# Altium schematic sheet VGA.SchDoc(VGA)
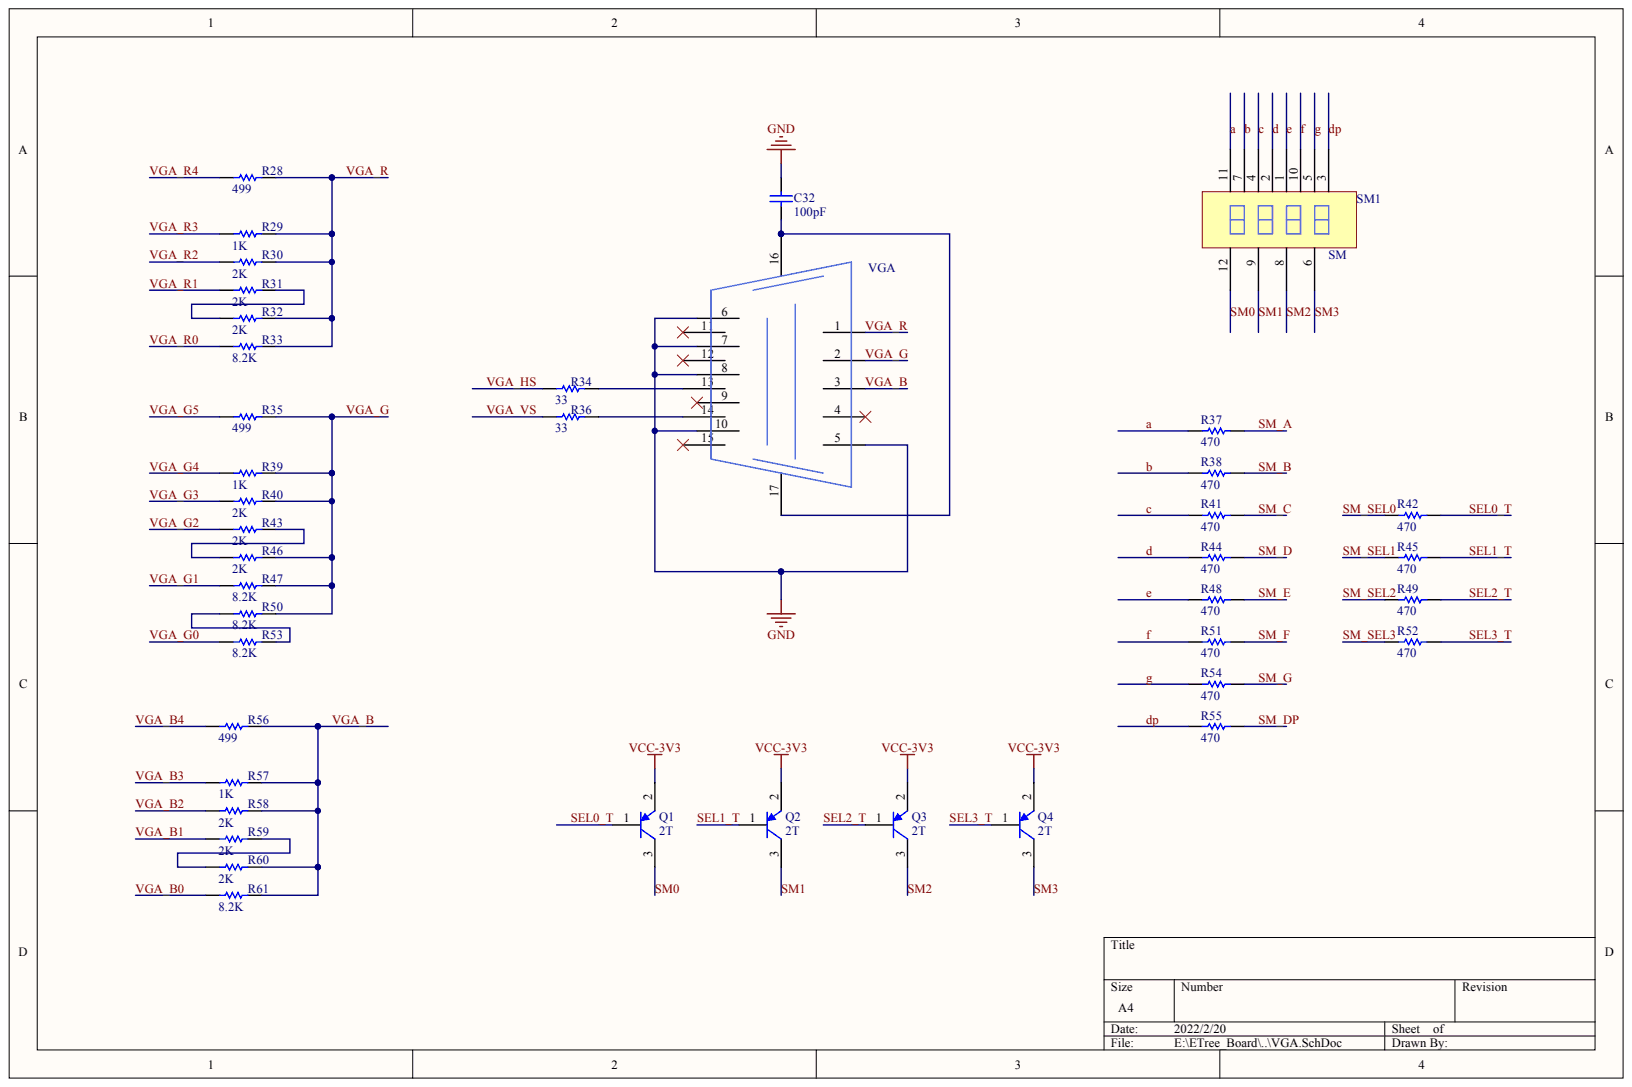
[diagram p0: VGA.SchDoc]

## Parts
C32  value 100pF  (hidden comment: Cap)
Q1  2T
Q2  2T
Q3  2T
Q4  2T
R28  value 499  (hidden comment: Res1)
R29  value 1K  (hidden comment: Res1)
R30  value 2K  (hidden comment: Res1)
R31  value 2K  (hidden comment: Res1)
R32  value 2K  (hidden comment: Res1)
R33  value 8.2K  (hidden comment: Res1)
R34  value 33  (hidden comment: Res1)
R35  value 499  (hidden comment: Res1)
R36  value 33  (hidden comment: Res1)
R37  value 470  (hidden comment: Res1)
R38  value 470  (hidden comment: Res1)
R39  value 1K  (hidden comment: Res1)
R40  value 2K  (hidden comment: Res1)
R41  value 470  (hidden comment: Res1)
R42  value 470  (hidden comment: Res1)
R43  value 2K  (hidden comment: Res1)
R44  value 470  (hidden comment: Res1)
R45  value 470  (hidden comment: Res1)
R46  value 2K  (hidden comment: Res1)
R47  value 8.2K  (hidden comment: Res1)
R48  value 470  (hidden comment: Res1)
R49  value 470  (hidden comment: Res1)
R50  value 8.2K  (hidden comment: Res1)
R51  value 470  (hidden comment: Res1)
R52  value 470  (hidden comment: Res1)
R53  value 8.2K  (hidden comment: Res1)
R54  value 470  (hidden comment: Res1)
R55  value 470  (hidden comment: Res1)
R56  value 499  (hidden comment: Res1)
R57  value 1K  (hidden comment: Res1)
R58  value 2K  (hidden comment: Res1)
R59  value 2K  (hidden comment: Res1)
R60  value 2K  (hidden comment: Res1)
R61  value 8.2K  (hidden comment: Res1)
SM1  SM
VGA1  VGA

## Nets
GND: C32.1 VGA1.5 VGA1.6 VGA1.7 VGA1.8 VGA1.10
VCC-3V3: Q1.2 Q2.2 Q3.2 Q4.2
A: R37.2 SM1.11
B: R38.2 SM1.7
C: R41.2 SM1.4
D: R44.2 SM1.2
DP: R55.2 SM1.3
E: R48.2 SM1.1
F: R51.2 SM1.10
G: R54.2 SM1.5
SEL0_T: Q1.1 R42.1
SEL1_T: Q2.1 R45.1
SEL2_T: Q3.1 R49.1
SEL3_T: Q4.1 R52.1
SM0: Q1.3 SM1.12
SM1: Q2.3 SM1.9
SM2: Q3.3 SM1.8
SM3: Q4.3 SM1.6
SM_A: R37.1
SM_B: R38.1
SM_C: R41.1
SM_D: R44.1
SM_DP: R55.1
SM_E: R48.1
SM_F: R51.1
SM_G: R54.1
SM_SEL0: R42.2
SM_SEL1: R45.2
SM_SEL2: R49.2
SM_SEL3: R52.2
VGA_B: R56.1 R57.1 R58.1 R60.1 R61.1 VGA1.3
VGA_B0: R61.2
VGA_B1: R59.2
VGA_B2: R58.2
VGA_B3: R57.2
VGA_B4: R56.2
VGA_G: R35.1 R39.1 R40.1 R46.1 R47.1 R50.1 VGA1.2
VGA_G0: R53.2
VGA_G1: R47.2
VGA_G2: R43.2
VGA_G3: R40.2
VGA_G4: R39.2
VGA_G5: R35.2
VGA_HS: R34.2
VGA_R: R28.1 R29.1 R30.1 R32.1 R33.1 VGA1.1
VGA_R0: R33.2
VGA_R1: R31.2
VGA_R2: R30.2
VGA_R3: R29.2
VGA_R4: R28.2
VGA_VS: R36.2
NetC32_2: C32.2 VGA1.16 VGA1.17
NetR31_1: R31.1 R32.2
NetR34_1: R34.1 VGA1.13
NetR36_1: R36.1 VGA1.14
NetR43_1: R43.1 R46.2
NetR50_2: R50.2 R53.1
NetR59_1: R59.1 R60.2

Not connected: VGA1 4 9 11 12 15

## Library data of the parts
C32 Q1-Q4 R28-R61 SM1 VGA1 = (no symbol name)
  C32: Published: 8-Jun-2000; LatestRevisionDate: 17-Jul-2002; LatestRevisionNote: Re-released for DXP Platform.; PackageReference: RAD-0.3; PackageDescription: Radial Cap, Thru-Hole; 2 Leads; 0.3 in Pin Spacing
  Q1-Q4: Published: 8-Jun-2000; LatestRevisionDate: 29-May-2009; LatestRevisionNote: IPC-7351 Footprint Added.; PackageVersion: Aug-1999; PackageReference: SOT-23B; PackageDescription: 3-Pin SOT-23 Package 0.95 mm Pitch, 2.4 mm Lead Span
  R28-R61: Published: 8-Jun-2000; LatestRevisionDate: 17-Jul-2002; LatestRevisionNote: Re-released for DXP Platform.; PackageReference: AXIAL-0.3; PackageDescription: Axial Device, Thru-Hole; 2 Leads; 0.3 in Pin Spacing
  C32 Q1-Q4 R28-R61: Publisher: Altium Limited
